# Altium schematic sheet SH6 - CAN.SchDoc
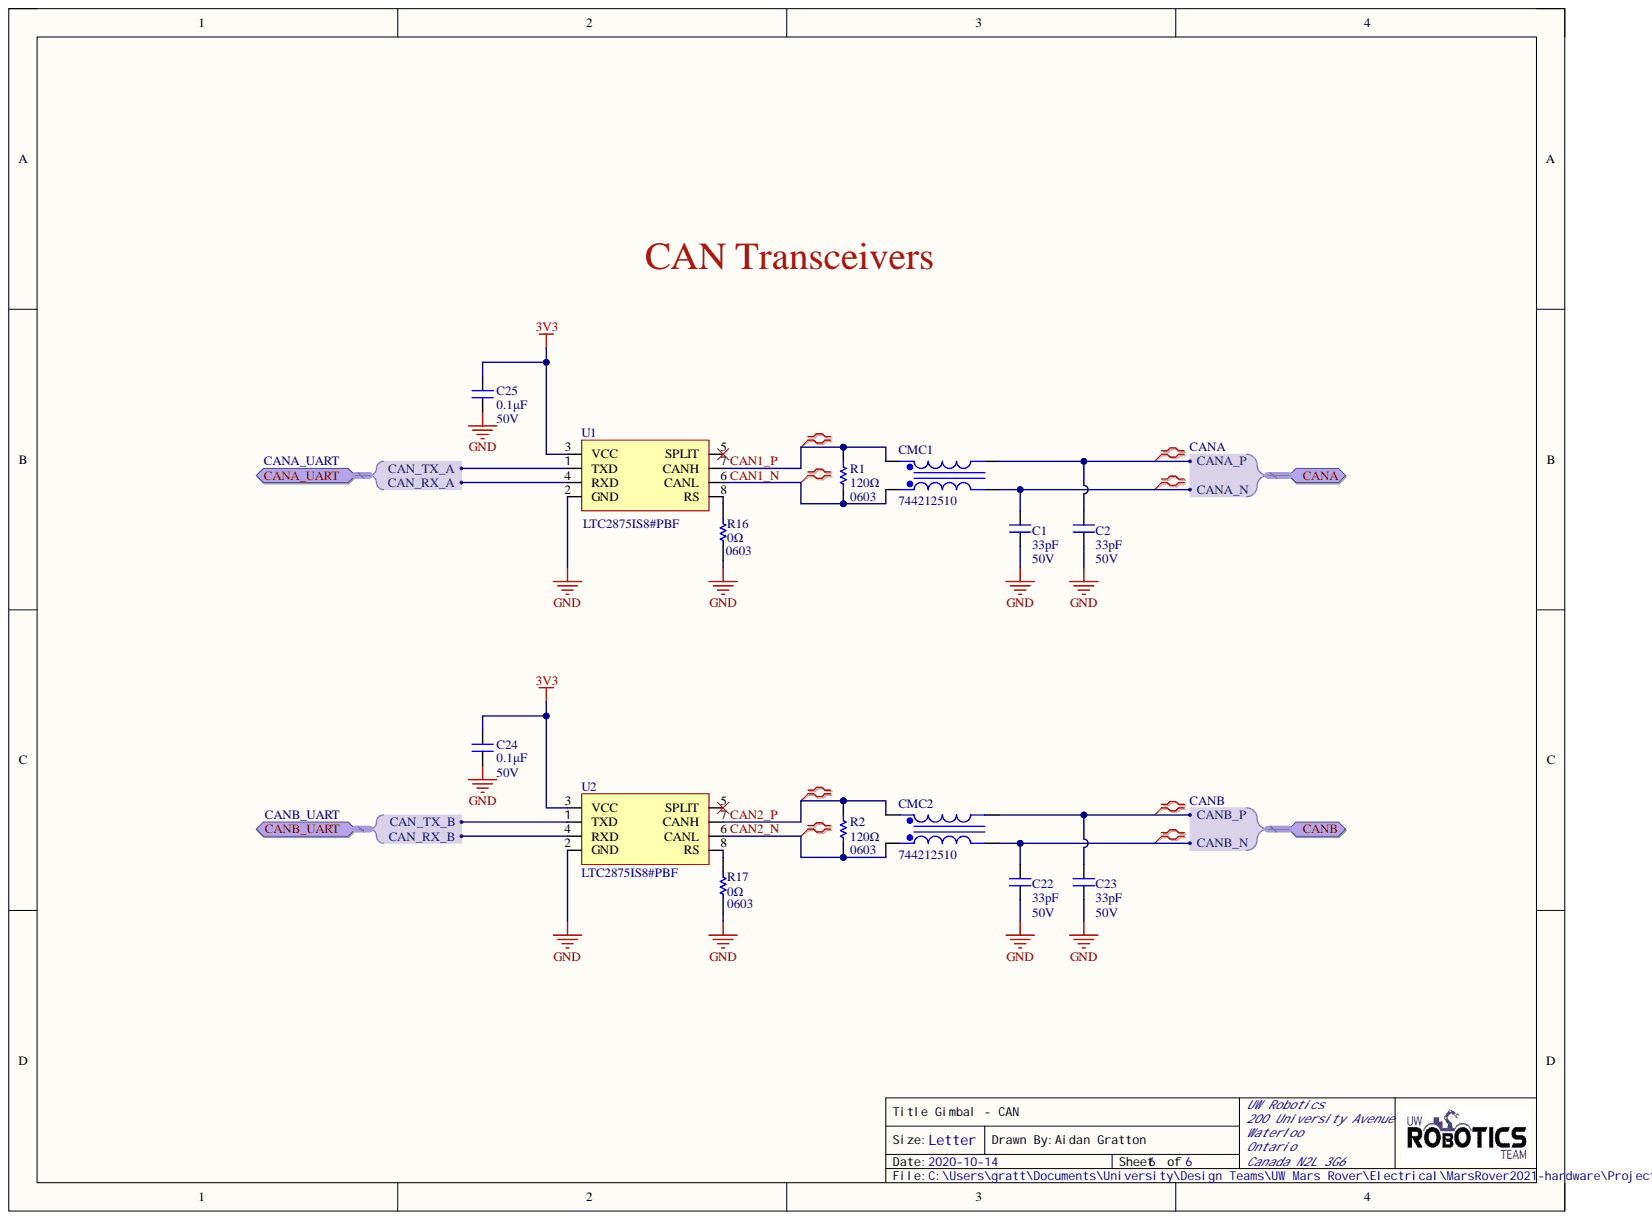
[diagram p0: SH6 - CAN.SchDoc]

## Parts
C1  value 33pF  Voltage: 50V  [CAP0603]  (hidden comment: CAP_33pF_50V_0603)
C2  value 33pF  Voltage: 50V  [CAP0603]  (hidden comment: CAP_33pF_50V_0603)
C22  value 33pF  Voltage: 50V  [CAP0603]  (hidden comment: CAP_33pF_50V_0603)
C23  value 33pF  Voltage: 50V  [CAP0603]  (hidden comment: CAP_33pF_50V_0603)
C24  value 0.1μF  Voltage Rating: 50V  [CAP0603]  (hidden comment: CAP_0.1uF_50V_0603)
C25  value 0.1μF  Voltage Rating: 50V  [CAP0603]  (hidden comment: CAP_0.1uF_50V_0603)
CMC1  CMC_51uH  [744212510]  (value not drawn)
CMC2  CMC_51uH  [744212510]  (value not drawn)
R1  value 120Ω  Case Code (Imperial): 0603  [RESC0603(1608)_N]  (hidden comment: RES_120Ω_0603)
R2  value 120Ω  Case Code (Imperial): 0603  [RESC0603(1608)_N]  (hidden comment: RES_120Ω_0603)
R16  value 0Ω  Case Code (Imperial): 0603  [RESC0603(1608)_N]  (hidden comment: RES_0Ω_0603)
R17  value 0Ω  Case Code (Imperial): 0603  [RESC0603(1608)_N]  (hidden comment: RES_0Ω_0603)
U1  Manufacturer Part Number 1: LTC2875IS8#PBF  [LT-S8_N]  (hidden comment: IC TXRX CAN)
U2  Manufacturer Part Number 1: LTC2875IS8#PBF  [LT-S8_N]  (hidden comment: IC TXRX CAN)

## Ports
CANA, CANA.CANA_N, CANA.CANA_P, CANA_UART, CANA_UART.CAN_RX_A, CANA_UART.CAN_TX_A, CANB, CANB.CANB_N, CANB.CANB_P, CANB_UART, CANB_UART.CAN_RX_B, CANB_UART.CAN_TX_B

## Nets
3V3: C24.1 C25.1 U1.3(VCC) U2.3(VCC)
GND: C1.1 C2.1 C22.1 C23.1 C24.2 C25.2 R16.1 R17.1 U1.2(GND) U2.2(GND)
CAN1_N: CMC1.1 R1.1 U1.6(CANL)
CAN1_P: CMC1.3 R1.2 U1.7(CANH)
CAN2_N: CMC2.1 R2.1 U2.6(CANL)
CAN2_P: CMC2.3 R2.2 U2.7(CANH)
CANA.CANA_N: C1.2 CMC1.5 ; port CANA
CANA.CANA_P: C2.2 CMC1.4 ; port CANA
CANA_UART.CAN_RX_A: U1.4(RXD) ; port CANA_UART
CANA_UART.CAN_TX_A: U1.1(TXD) ; port CANA_UART
CANB.CANB_N: C22.2 CMC2.5 ; port CANB
CANB.CANB_P: C23.2 CMC2.4 ; port CANB
CANB_UART.CAN_RX_B: U2.4(RXD) ; port CANB_UART
CANB_UART.CAN_TX_B: U2.1(TXD) ; port CANB_UART
NetR16_2: R16.2 U1.8(RS)
NetR17_2: R17.2 U2.8(RS)

Not connected: U1 5(SPLIT); U2 5(SPLIT)

## Library data of the parts
C1 C2 C22 C23 = CAP_33pF_50V_0603: CAP CER 33PF 50V C0G/NP0; Manufacturer 1: Yageo; Manufacturer Part Number 1: CC0603JRNPO9BN330; Dielectric: C0G; Dielectric Material: Ceramic; Insulation Resistance: 100GR; Temperature Coefficient: 30ppm/°C; Tolerance: 5%; Case Code (Imperial): 0603; Case Code (Metric): 1608; Component Kind: Standard; ComponentLink7Description: https://datasheet.ciiva.com/11464/upy-gp-np0-16v-to-50v-8-11464730.pdf?src-supplier=Avnet; ComponentLink7URL: https://datasheet.ciiva.com/11464/upy-gp-np0-16v-to-50v-8-11464730.pdf?src-supplier=Avnet; ComponentLink8Description: https://datasheet.ciiva.com/46430/1835847-46430155.pdf?src-supplier=Element14; ComponentLink8URL: https://datasheet.ciiva.com/46430/1835847-46430155.pdf?src-supplier=Element14; ComponentLink9Description: https://datasheet.ciiva.com/144/mlccorderingcode-144644.pdf?src-supplier=Digi-Key; ComponentLink9URL: https://datasheet.ciiva.com/144/mlccorderingcode-144644.pdf?src-supplier=Digi-Key; ComponentLink10Description: https://datasheet.ciiva.com/5426/upy-gp-np0-16v-to-50v-8-5426614.pdf?src-supplier=Mouser; ComponentLink10URL: https://datasheet.ciiva.com/5426/upy-gp-np0-16v-to-50v-8-5426614.pdf?src-supplier=Mouser; ComponentLink11Description: https://datasheet.ciiva.com/7978/1443161-7978142.pdf?src-supplier=Element14; ComponentLink11URL: https://datasheet.ciiva.com/7978/1443161-7978142.pdf?src-supplier=Element14; Composition: Ceramic; Depth: 0.8mm; Height: 0.8mm; Length: 1.6mm; Max Operating Temperature: 125°C; Min Operating Temperature: -55°C; Mount: Surface Mount; Number of Pins: 2; Package: 0603; REACH SVHC: No SVHC; Supplier 1: Digi-Key; Supplier Part Number 1: 311-1064-1-ND; Termination: SMD/SMT; Thickness: 0.035inch; Voltage Rating: 50V; Voltage Rating (DC): 50V; Width: 0.031inch; Library Name: UWRT_Schematic_Library_2020.SchLib
C24 C25 = CAP_0.1uF_50V_0603: CAP CER 0.1UF 50V X7R 0603; Manufacturer 1: Yageo; Manufacturer Part Number 1: CC0603KRX7R9BB104; Case Code (Imperial): 0603; Case Code (Metric): 1608; Component Kind: Standard; Dielectric: X7R; Dimensions: 0.063" L x 0.031" W (1.60mm x 0.80mm); Mount: Surface Mount; Package: 0603 (1608 Metric); Signal Integrity: Cap; Simulation: CAP; Supplier 1: Digi-Key; Supplier Part Number 1: 311-1344-1-ND; Temperature Coefficient: X7R; Library Name: UWRT_Schematic_Library_2020.SchLib
CMC1 CMC2 = CMC_51uH: CMC 51uH 300MA 2LN SMD; Manufacturer 1: Wurth Electronics; Core Material: Ferrite; DC Resistance (DCR): 160mR; Impedance: 300R; Inductance Tolerance: 50%; Number of Lines: 2; Test Frequency: 100kHz; Voltage Rating (DC): 80V; Case/Package: SMD/SMT; Component Kind: Standard; ComponentLink7Description: https://datasheet.ciiva.com/6216/744212510-6216348.pdf?src-supplier=Digi-Key; ComponentLink7URL: https://datasheet.ciiva.com/6216/744212510-6216348.pdf?src-supplier=Digi-Key; ComponentLink8Description: https://datasheet.ciiva.com/7764/1735560-7764951.pdf?src-supplier=Element14; ComponentLink8URL: https://datasheet.ciiva.com/7764/1735560-7764951.pdf?src-supplier=Element14; Current: 300mA; Current Rating: 300mA; Depth: 3.6mm; Height: 1.65mm; Inductance: 51uH; Length: 6.5mm; Manufacturer Part Number 1: 744212510; Max DC Current: 300mA; Max Operating Temperature: 105°C; Min Operating Temperature: -40°C; Mount: Surface Mount; Number of Pins: 4; REACH SVHC: No SVHC; Supplier 1: Digi-Key; Supplier Part Number 1: 732-3941-1-ND; Termination: SMD/SMT; Tolerance: 50%; Width: 0.142inch; Library Name: UWRT_Schematic_Library_2020.SchLib
R1 R2 = RES_120Ω_0603: RES SMD 120 OHM 5% 1/10W 0603; Manufacturer 1: Yageo; Manufacturer Part Number 1: RC0603JR-07120RL; Case Code (Metric): 1608; Component Kind: Standard; Dimensions: 0.063" L x 0.031" W (1.60mm x 0.80mm); Mount: Surface Mount; Package: 0603 (1608 Metric); Signal Integrity: Res; Simulation: RESISTOR; Supplier 1: Digi-Key; Supplier Part Number 1: 311-120GRCT-ND; Tolerance: 5%; Library Name: UWRT_Schematic_Library_2020.SchLib
R16 R17 = RES_0Ω_0603: RES SMD 0 OHM JUMPER 1/10W 0603; Manufacturer 1: Yageo; Manufacturer Part Number 1: RC0603JR-070RL; Case Code (Metric): 1608; Component Kind: Standard; Dimensions: 0.063" L x 0.031" W (1.60mm x 0.80mm); Mount: Surface Mount; Package: 0603 (1608 Metric); Signal Integrity: Res; Simulation: RESISTOR; Supplier 1: Digi-Key; Supplier Part Number 1: 311-0.0GRCT-ND; Library Name: UWRT_Schematic_Library_2020.SchLib
U1 U2 = LTC2875: IC TXRX CAN 4MBPS 8SO; Manufacturer 1: Linear Technologies; Component Kind: Standard; Mount: Surface Mount; Package: 8-SOIC (0.154", 3.90mm Width); Supplier 1: Digi-Key; Supplier Part Number 1: LTC2875IS8#PBF-ND; Library Name: UWRT_Schematic_Library_2020.SchLib
